# Altium schematic sheet Eco-Stationnement_NFC.SchDoc(Eco-Stationnement_NFC)
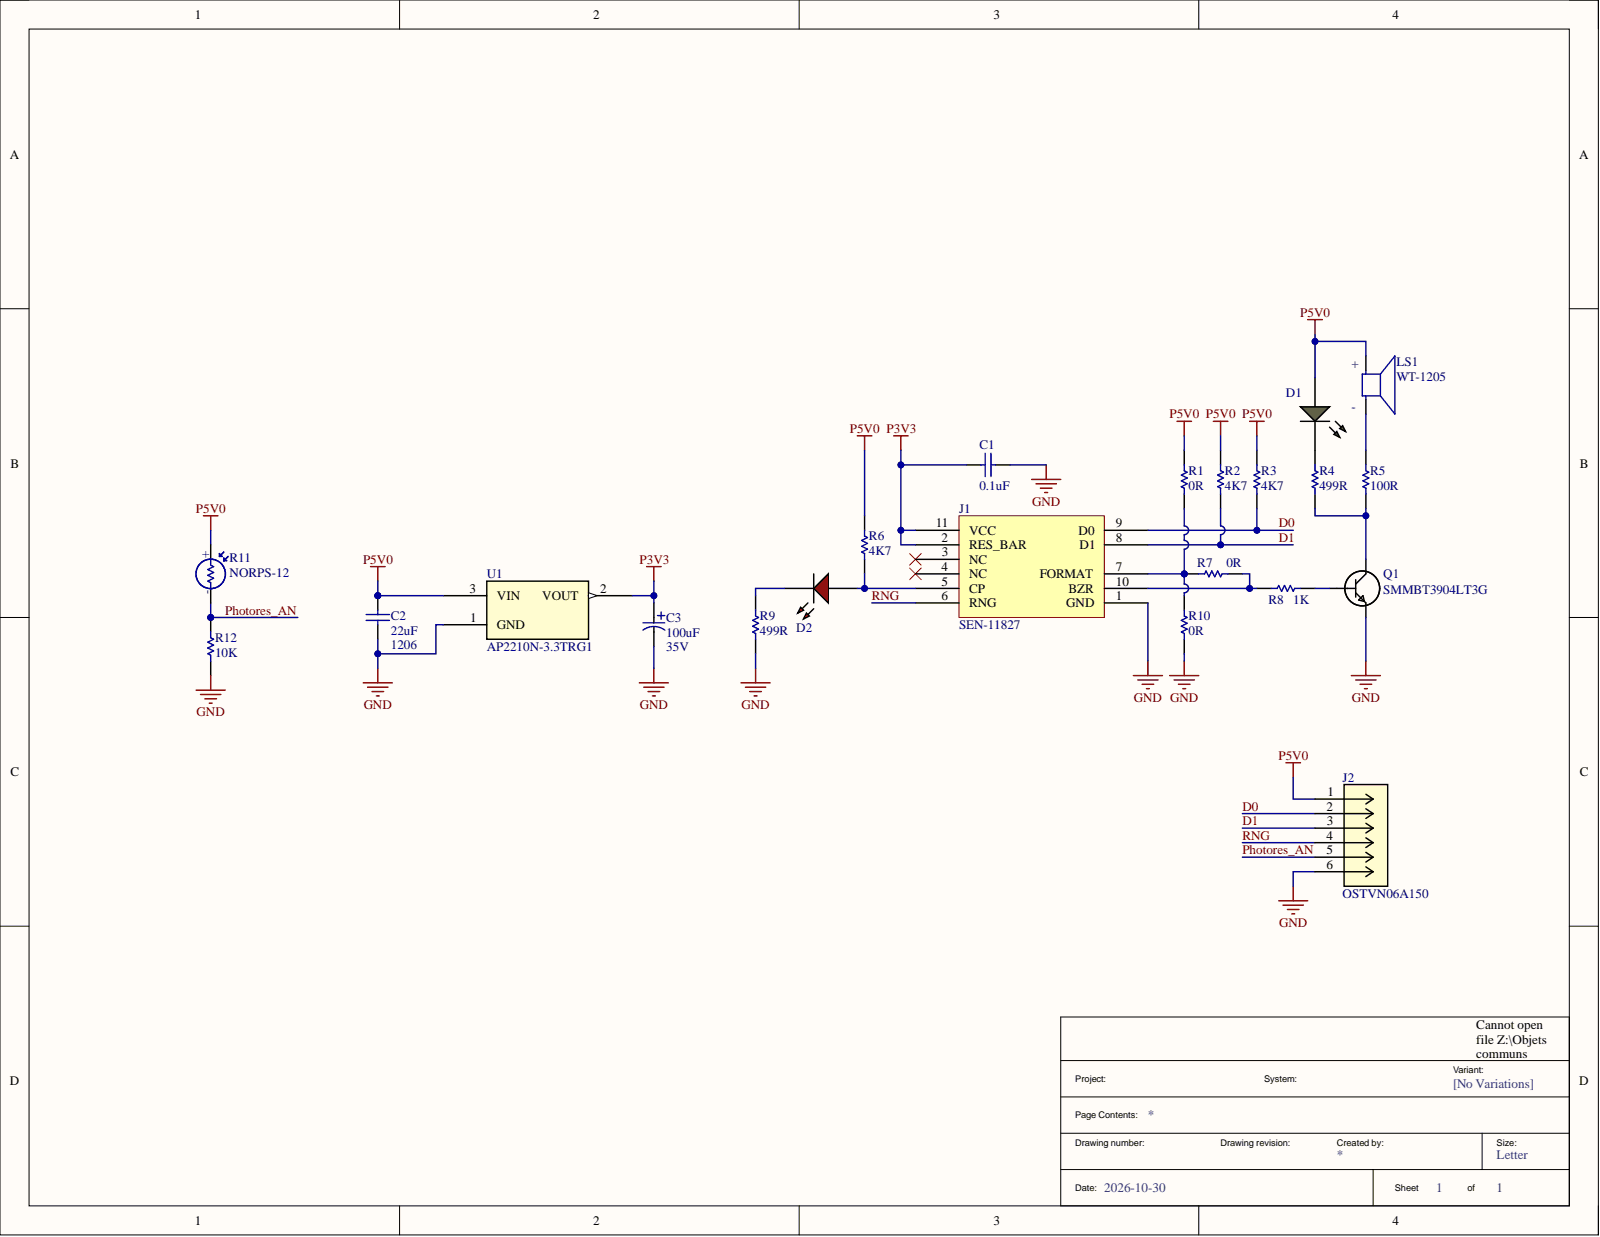
[diagram p0: Eco-Stationnement_NFC.SchDoc]

## Parts
C1  value 0.1uF  [C0603]  (hidden comment: 06035C104KAZ2A)
C2  value 22uF  Package: 1206  [C1206]  (hidden comment: CL31A226MOCLNNC)
C3  value 100uF  Voltage: 35V  [WCAP-ASLI_6.3X7.7(DXL)]  (hidden comment: 865080545012)
D1  LTST-C191KGKT  [D0603]  (value not drawn)
D2  LTST-C190KRKT  [D0603]  (value not drawn)
J1  SEN-11827  [CONN11-11827_SPK]
J2  OSTVN06A150  [CONN_OSTVN06A150_OST]
LS1  WT-1205  [WT-1205]
Q1  SMMBT3904LT3G  [SOT_4LT3G_ONS]
R1  value 0R  [R0603]  (hidden comment: RC0603JR-070RL)
R2  value 4K7  [R0603]  (hidden comment: RC0603FR-074K7L)
R3  value 4K7  [R0603]  (hidden comment: RC0603FR-074K7L)
R4  value 499R  [R0603]  (hidden comment: RT0603BRD07499RL)
R5  value 100R  [R0603]  (hidden comment: AC0603FR-07100RL)
R6  value 4K7  [R0603]  (hidden comment: RC0603FR-074K7L)
R7  value 0R  [R0603]  (hidden comment: RC0603JR-070RL)
R8  value 1K  [R0603]  (hidden comment: RC0603FR-071KL)
R9  value 499R  [R0603]  (hidden comment: RT0603BRD07499RL)
R10  value 0R  [R0603]  (hidden comment: RC0603JR-070RL)
R11  NORPS-12  [IC2_NORPS-12_ADP]
R12  value 10K  [R0603]  (hidden comment: RC0603FR-0710KL)
U1  AP2210N-3.3TRG1  [SOT_3.3TRG1_DIO-L]

## Nets
GND: C1.2 C2.1 C3.2 J1.1(GND) J2.6 Q1.3 R9.1 R10.1 R12.1 U1.1
P3V3: C1.1 C3.1 J1.2(RES_BAR) J1.11(VCC) U1.2
P5V0: C2.2 D1.1 J2.1 LS1.P R1.2 R2.2 R3.2 R6.2 R11.1 U1.3
D0: J1.9(D0) J2.2 R3.1
D1: J1.8(D1) J2.3 R2.1
Photores_AN: J2.5 R11.2 R12.2
RNG: J1.6(RNG) J2.4
NetD1_2: D1.2 R4.2
NetD2_1: D2.1 J1.5(CP) R6.1
NetD2_2: D2.2 R9.2
NetJ1_7: J1.7(FORMAT) R1.1 R7.1 R10.2
NetJ1_10: J1.10(BZR) R7.2 R8.1
NetLS1_N: LS1.N R5.2
NetQ1_1: Q1.1 R8.2
NetQ1_2: Q1.2 R4.1 R5.1

Not connected: J1 3(NC) 4(NC)

## Library data of the parts
C1 = CAP0021: CAP CER 0.1UF 50V X7R 0805; Manufacturer: Yageo; ID_CEP: MCAP0021; Verif_1: JSMG; Component Kind: Standard; Cost: 0.08; Fitted: Fitted; LatestRevisionDate: 17-Jul-2002; LatestRevisionNote: Re-released for DXP Platform.; Package: 0603; PackageDescription: Radial Cap, Thru-Hole; 2 Leads; 0.3 in Pin Spacing; PN_Manuf: 06035C104KAZ2A; Published: 8-Jun-2000; Library Name: Eco-Stationnement_NFC.SchLib; Publisher: Altium Limited
C2 = CAP0009: CAP CER 22UF 16V X5R 1206; Manufacturer: Samsung; ID_CEP: MCAP0009; Verif_1: JSMG; Component Kind: Standard; Cost: 0.1; Fitted: Fitted; LatestRevisionDate: 17-Jul-2002; LatestRevisionNote: Re-released for DXP Platform.; PackageDescription: Radial Cap, Thru-Hole; 2 Leads; 0.3 in Pin Spacing; PackageReference: CC0805KKX7R9BB105; PN_Manuf: CL31A226MOCLNNC; Published: 8-Jun-2000; Library Name: Eco-Stationnement_NFC.SchLib; Publisher: Altium Limited
C3 = 865080545012: CAP ALUM 100UF 20% 35V SMD; Manufacturer: Würth Elektronik; Component Kind: Standard; Fitted: Fitted; Package: 0603; PN_Manuf: 865080545012; Library Name: Eco-Stationnement_NFC.SchLib
D1 = DIO0070: LED GREEN CLEAR SMD; Manufacturer: Lite-On Inc.; ID_CEP: MDIO0070; Value: X; Verif_1: JSMG; Component Kind: Standard; Cost: 0.2; Fitted: Fitted; Package: 0603-P; PN_Manuf: LTST-C191KGKT; Library Name: Eco-Stationnement_NFC.SchLib
D2 = LTST-C190KRKT: LED RED CLEAR CHIP SMD; Manufacturer: Lite-On Inc.; ID_CEP: MDIO0070; Value: X; Verif_1: JSMG; Component Kind: Standard; Cost: 0.2; Fitted: Fitted; Package: 0603-P; PN_Manuf: LTST-C190KRKT; Library Name: Eco-Stationnement_NFC.SchLib
J1 = SEN-11827: RFID READER ID-12LA (125 KHZ); Manufacturer: SparkFun Electronics; Component Kind: Standard; Fitted: Fitted; PN_Manuf: SEN-11827; Library Name: Eco-Stationnement_NFC.SchLib
J2 = OSTVN06A150: TERM BLK 6P SIDE ENT 2.54MM PCB; Manufacturer: On Shore Technology Inc.; Manufacturer_Name: On Shore Technology; Component Kind: Standard; Fitted: Fitted; Manufacturer_Part_Number: OSTVN06A150; PN_Manuf: OSTVN06A150; RefDes: RefDes; Type: Type; Copyright: Copyright (C) 2025 Ultra Librarian. All rights reserved.; Library Name: Eco-Stationnement_NFC.SchLib
LS1 = WT-1205: BUZZER MAGNETIC 5V 12MM TH; Manufacturer: Soberton Inc.; Availability: Unavailable; Component Kind: Standard; Fitted: Fitted; MP: WT-1205; Package: None; PN_Manuf: WT-1205; Price: None; Library Name: Eco-Stationnement_NFC.SchLib
Q1 = SMMBT3904LT3G: TRANS NPN 40V 0.2A SOT23-3; Manufacturer: onsemi; Component Kind: Standard; Fitted: Fitted; Manufacturer_Name: onsemi; Manufacturer_Part_Number: SMMBT3904LT3G; PN_Manuf: SMMBT3904LT3G; Copyright: Copyright (C) 2025 Ultra Librarian. All rights reserved.; Library Name: Eco-Stationnement_NFC.SchLib
R1 R7 R10 = RES0022: RES SMD 0 OHM 1% 1/10W 0603; Manufacturer: Yageo; ID_CEP: MRES0022; Verif_1: JSMG; Component Kind: Standard; Cost: 0.01; Fitted: Fitted; LatestRevisionDate: 17-Jul-2002; LatestRevisionNote: Re-released for DXP Platform.; Package: 0603; PackageDescription: Axial Device, Thru-Hole; 2 Leads; 0.3 in Pin Spacing; PackageReference: AXIAL-0.3; PN_Manuf: RC0603JR-070RL; Published: 8-Jun-2000; Library Name: Eco-Stationnement_NFC.SchLib; Publisher: Altium Limited
R2 R3 R6 = RES0024: RES SMD 4K7 OHM 1% 1/10W 0603; Manufacturer: Yageo; ID_CEP: MRES0024; Verif_1: JSMG; Component Kind: Standard; Cost: 0.01; Fitted: Fitted; LatestRevisionDate: 17-Jul-2002; LatestRevisionNote: Re-released for DXP Platform.; Package: 0603; PackageDescription: Axial Device, Thru-Hole; 2 Leads; 0.3 in Pin Spacing; PackageReference: AXIAL-0.3; PN_Manuf: RC0603FR-074K7L; Published: 8-Jun-2000; Library Name: Eco-Stationnement_NFC.SchLib; Publisher: Altium Limited
R4 R9 = RES0015: RES SMD 499R OHM 1% 1/10W 0603; Manufacturer: Yageo; ID_CEP: MRES0018; Verif_1: JSMG; Component Kind: Standard; Cost: 0.01; Fitted: Fitted; LatestRevisionDate: 17-Jul-2002; LatestRevisionNote: Re-released for DXP Platform.; Package: 0603; PackageDescription: Axial Device, Thru-Hole; 2 Leads; 0.3 in Pin Spacing; PackageReference: AXIAL-0.3; PN_Manuf: RT0603BRD07499RL; Published: 8-Jun-2000; Library Name: Eco-Stationnement_NFC.SchLib; Publisher: Altium Limited
R5 = RES0018: RES SMD 100R OHM 1% 1/10W 0603; Manufacturer: Yageo; ID_CEP: MRES0018; Verif_1: JSMG; Component Kind: Standard; Cost: 0.01; Fitted: Fitted; LatestRevisionDate: 17-Jul-2002; LatestRevisionNote: Re-released for DXP Platform.; Package: 0603; PackageDescription: Axial Device, Thru-Hole; 2 Leads; 0.3 in Pin Spacing; PackageReference: AXIAL-0.3; PN_Manuf: AC0603FR-07100RL; Published: 8-Jun-2000; Library Name: Eco-Stationnement_NFC.SchLib; Publisher: Altium Limited
R8 = RES0001: RES SMD 1K OHM 1% 1/10W 0603; Manufacturer: Yageo; ID_CEP: MRES0001; Verif_1: JSMG; Component Kind: Standard; Cost: 0.01; Fitted: Fitted; LatestRevisionDate: 17-Jul-2002; LatestRevisionNote: Re-released for DXP Platform.; Package: 0603; PackageDescription: Axial Device, Thru-Hole; 2 Leads; 0.3 in Pin Spacing; PackageReference: AXIAL-0.3; PN_Manuf: RC0603FR-071KL; Published: 8-Jun-2000; Library Name: Eco-Stationnement_NFC.SchLib; Publisher: Altium Limited
R11 = NORPS-12: CDS PHOTORESISTOR; Manufacturer: Advanced Photonix; Component Kind: Standard; Fitted: Fitted; Manufacturer_Name: Advanced Photonix; Manufacturer_Part_Number: NORPS-12; PN_Manuf: NORPS-12; RefDes: RefDes; Type: Type; Copyright: Copyright (C) 2025 Ultra Librarian. All rights reserved.; Library Name: Eco-Stationnement_NFC.SchLib
R12 = RES0002: RES SMD 10K OHM 1% 1/10W 0603; Manufacturer: YAGEO; ID_CEP: MRES0002; Verif_1: JSMG; Component Kind: Standard; Cost: 0.01; Fitted: Fitted; LatestRevisionDate: 17-Jul-2002; LatestRevisionNote: Re-released for DXP Platform.; Package: 0603; PackageDescription: Axial Device, Thru-Hole; 2 Leads; 0.3 in Pin Spacing; PackageReference: AXIAL-0.3; PN_Manuf: RC0603FR-0710KL; Published: 8-Jun-2000; Library Name: Eco-Stationnement_NFC.SchLib; Publisher: Altium Limited
U1 = AP2210N-3.3TRG1: IC REG LINEAR 3.3V 300MA SOT23-3; Manufacturer: Diodes Incorporated; Manufacturer_Name: Diodes Inc; Component Kind: Standard; Fitted: Fitted; Manufacturer_Part_Number: AP2210N-3.3TRG1; PN_Manuf: AP2210N-3.3TRG1; RefDes: RefDes; Type: Type; Copyright: Copyright (C) 2025 Ultra Librarian. All rights reserved.; Library Name: Eco-Stationnement_NFC.SchLib
